# Altium schematic sheet Motor-E2.SchDoc(Motor-E2)
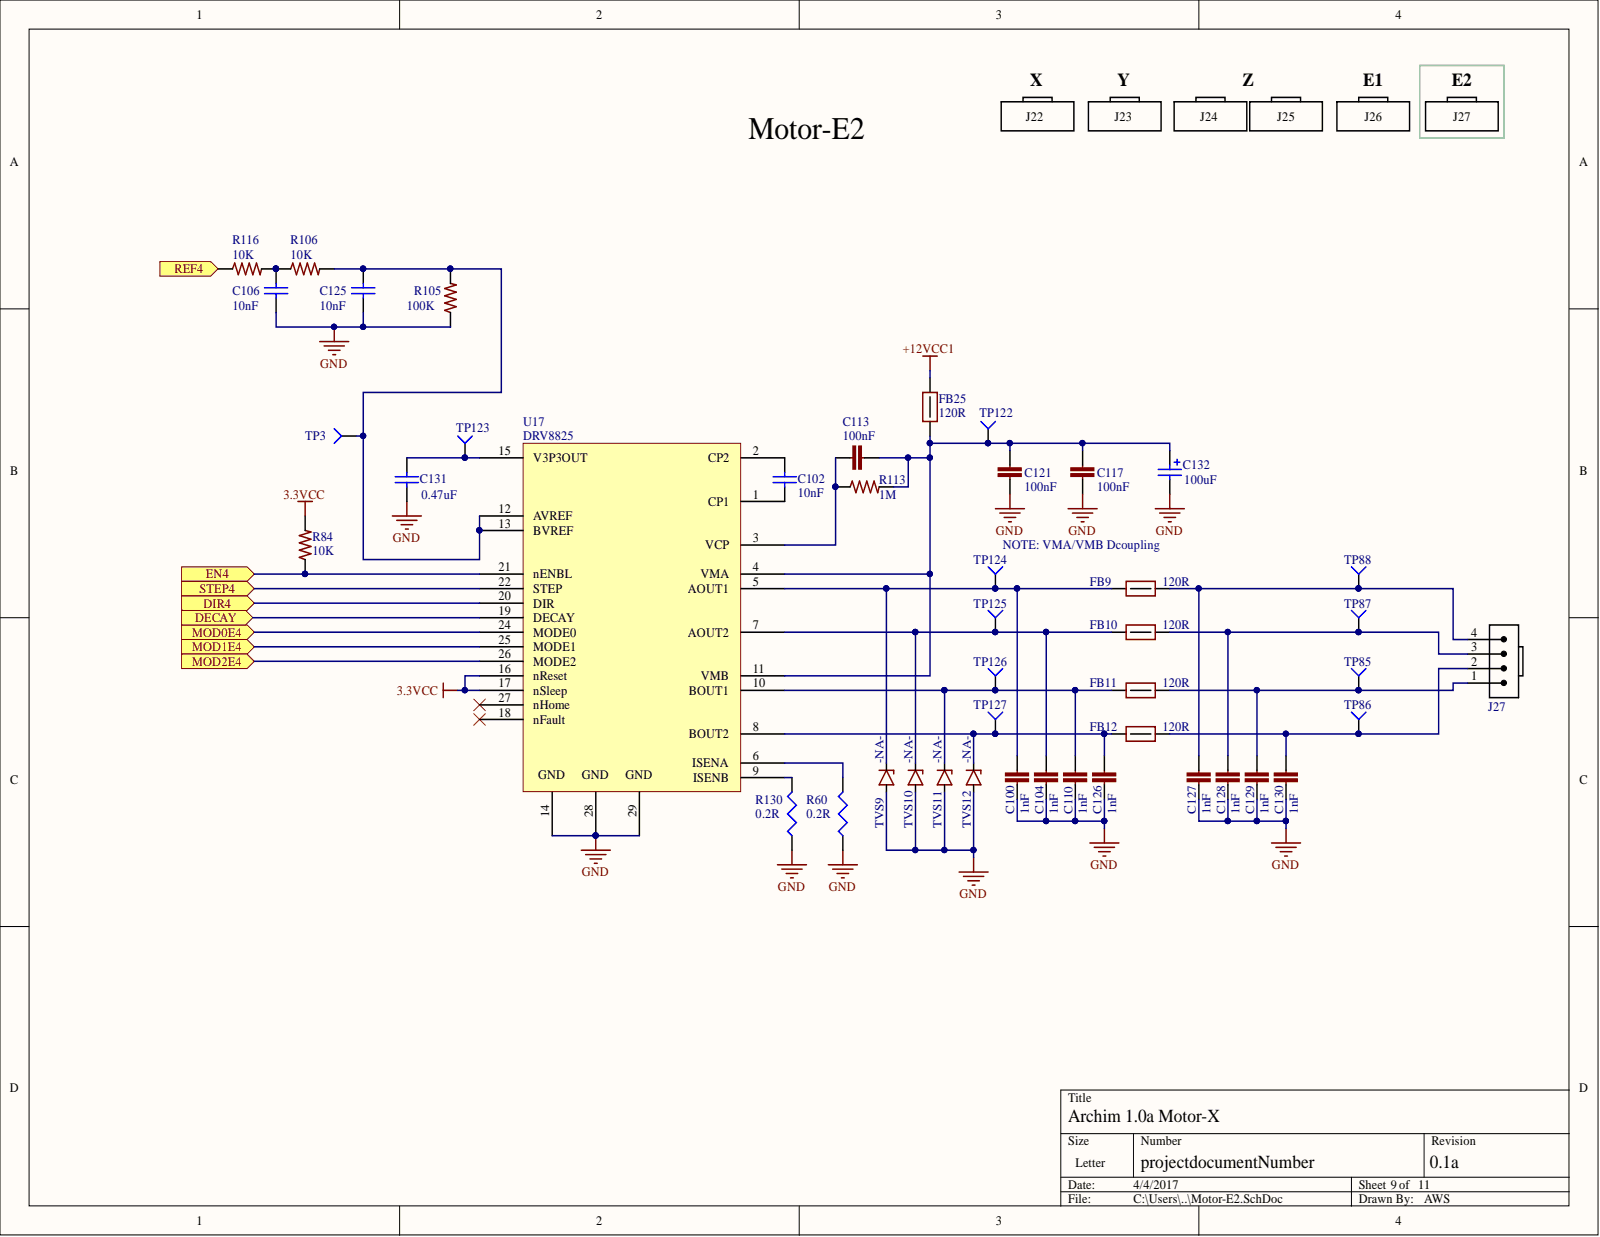
[diagram p0: Motor-E2.SchDoc]

## Parts
C100  1nF  [C0402]
C102  10nF  [C0402]
C104  1nF  [C0402]
C106  10nF  [C0402]
C110  1nF  [C0402]
C113  100nF  [C0402]
C117  100nF  [C0603]
C121  100nF  [C0603]
C125  10nF  [C0402]
C126  1nF  [C0402]
C127  1nF  [C0402]
C128  1nF  [C0402]
C129  1nF  [C0402]
C130  1nF  [C0402]
C131  value 0.47uF  [C0402]  (hidden comment: 0402)
C132  value 100uF  [CAP-ELEC-063063077]  (hidden comment: UWT1V101MCL1GS)
FB9  120R  [FB0603]
FB10  120R  [FB0603]
FB11  120R  [FB0603]
FB12  120R  [FB0603]
FB25  120R  [FB0603]
J27  Molex 0705430003  [4POL254]  (value not drawn)
R60  value 0.2R  [2512R-0.55]  (hidden comment: 2512)
R84  10K  [R0402]
R105  100K  [R0402]
R106  10K  [R0402]
R113  1M  [R0402]
R116  10K  [R0402]
R130  value 0.2R  [2512R-0.55]  (hidden comment: 2512)
TP3  TP4  [TP-2]  (value not drawn)  (no BOM)
TP85  TP4  [TP-2]  (value not drawn)  (no BOM)
TP86  TP4  [TP-2]  (value not drawn)  (no BOM)
TP87  TP4  [TP-2]  (value not drawn)  (no BOM)
TP88  TP4  [TP-2]  (value not drawn)  (no BOM)
TP122  TP4  [TP-2]  (value not drawn)  (no BOM)
TP123  TP4  [TP-2]  (value not drawn)  (no BOM)
TP124  TP4  [TP-2]  (value not drawn)  (no BOM)
TP125  TP4  [TP-2]  (value not drawn)  (no BOM)
TP126  TP4  [TP-2]  (value not drawn)  (no BOM)
TP127  TP4  [TP-2]  (value not drawn)  (no BOM)
TVS9  value -NA-  [SMF]  (hidden comment: TVS 30V SMF)
TVS10  value -NA-  [SMF]  (hidden comment: TVS 30V SMF)
TVS11  value -NA-  [SMF]  (hidden comment: TVS 30V SMF)
TVS12  value -NA-  [SMF]  (hidden comment: TVS 30V SMF)
U17  DRV8825  [DRV8825PWPR]

## Ports
DECAY, DIR4, EN4, MOD0E4, MOD1E4, MOD2E4, REF4, STEP4

## Nets
3.3VCC: R84.2 U17.16(nReset) U17.17(nSleep)
+12VCC1: FB25.2
GND: C100.2 C104.2 C106.1 C110.2 C117.2 C121.2 C125.1 C126.2 C127.2 C128.2 C129.2 C130.2 C131.1 C132.2 R60.1 R105.1 R130.1 TVS9.A TVS10.A TVS11.A TVS12.A U17.14 U17.28 U17.29
DECAY: U17.19(DECAY) ; port DECAY
DIR4: U17.20(DIR) ; port DIR4
EN4: R84.1 U17.21(nENBL) ; port EN4
MOD0E4: U17.24(MODE0) ; port MOD0E4
MOD1E4: U17.25(MODE1) ; port MOD1E4
MOD2E4: U17.26(MODE2) ; port MOD2E4
REF4: R116.1 ; port REF4
STEP4: U17.22(STEP) ; port STEP4
NetC100_1: C100.1 FB9.1 TP124.1 TVS9.K U17.5(AOUT1)
NetC102_1: C102.1 U17.1(CP1)
NetC102_2: C102.2 U17.2(CP2)
NetC104_1: C104.1 FB10.1 TP125.1 TVS10.K U17.7(AOUT2)
NetC106_2: C106.2 R106.1 R116.2
NetC110_1: C110.1 FB11.1 TP126.1 TVS11.K U17.10(BOUT1)
NetC113_1: C113.1 R113.1 U17.3(VCP)
NetC113_2: C113.2 C117.1 C121.1 C132.1 FB25.1 R113.2 TP122.1 U17.4(VMA) U17.11(VMB)
NetC125_2: C125.2 R105.2 R106.2 TP3.1 U17.12(AVREF) U17.13(BVREF)
NetC126_1: C126.1 FB12.1 TP127.1 TVS12.K U17.8(BOUT2)
NetC127_1: C127.1 FB9.2 J27.4 TP88.1
NetC128_1: C128.1 FB10.2 J27.3 TP87.1
NetC129_1: C129.1 FB11.2 J27.1 TP85.1
NetC130_1: C130.1 FB12.2 J27.2 TP86.1
NetC131_2: C131.2 TP123.1 U17.15(V3P3OUT)
NetR60_2: R60.2 U17.6(ISENA)
NetR130_2: R130.2 U17.9(ISENB)

Not connected: U17 18(nFault) 27(nHome)

## Library data of the parts
C100 C104 C110 C126-C130 = CAP 1nF 50V 0402 X7R: 1000pF 50V Ceramic Capacitor X7R 0402 (1005 Metric) 0.039" L x 0.020" W (1.00mm x 0.50mm); PART DESCRIPTION: 1000pF ±10% 50V Ceramic Capacitor X7R 0402 (1005 Metric); PART: 1nF 50V X7R 0402; MANUFACTURER #: GRM155R71H102KA01D; MANUFACTURER: Murata; TOLERANCE: 10%; VALUE: 1nF; Library Name: 3Delight.SCHLIB; EE_SPEC: 50V; DISTRIBUTOR #: 490-1303-2-ND; DISTRIBUTOR: Digikey; Component Kind: Standard
C102 C106 C125 = GRM155R71H103JA88D: CAP CER 10nF 50V X7R 0402; PART DESCRIPTION: 10000pF ±5% 50V Ceramic Capacitor X7R 0402 (1005 Metric); MANUFACTURER: Murata; TOLERANCE: 5%; VALUE: 10nF; Simulation: CAP; Signal Integrity: Cap Semi; PART: 10nF 50V X7R 0402; MANUFACTURER #: GRM155R71H103JA88D; Library Name: Tigershark3D.SchLib; EE_SPEC: 50V; DISTRIBUTOR #: 490-7763-1-ND; DISTRIBUTOR: Digikey; Component Kind: Standard
C113 = GRM155R71C104KA88D: CAP CER 0402 100nF 16V 10% X7R; PART DESCRIPTION: 0.10µF ±10% 16V Ceramic Capacitor X7R 0402 (1005 Metric); PART: 0.1uF 16V X7R 0402; MANUFACTURER: Murata; VALUE: 100nF; TOLERANCE: 10%; Simulation: Model Name; Signal Integrity: Model Name; MANUFACTURER #: GRM155R71C104KA88D; Library Name: 3Delight.SCHLIB; EE_SPEC: 16V; DISTRIBUTOR #: 490-3261-2-ND; DISTRIBUTOR: Digikey; Component Kind: Standard
C117 C121 = C0603C104K5RACTU: CAP CER 0603 100nF 50V 10% X7R; PART DESCRIPTION: 0.10µF ±10% 50V Ceramic Capacitor X7R 0603 (1608 Metric); PART: 100nF 50V X7R 0603; MANUFACTURER: Kemet; VALUE: 100nF; TOLERANCE: 10%; Simulation: Model Name; Signal Integrity: Model Name; MANUFACTURER #: C0603C104K5RACTU; Library Name: 3Delight.SCHLIB; EE_SPEC: 50V; DISTRIBUTOR #: 399-5089-2-ND; DISTRIBUTOR: Digikey; Component Kind: Standard
C131 = GRM155R60J474KE19D: 0.47uF 6.3v C0402 - GRM155R60J474KE19D; PART DESCRIPTION: 0.47µF ±10% 6.3V Ceramic Capacitor X5R 0402 (1005 Metric); PART: 0.47uF 6.3V X5R 0402; MANUFACTURER: Murata; TOLERANCE: 10%; Simulation: CAP; Signal Integrity: Cap Semi; MANUFACTURER #: GRM155R60J474KE19D; Library Name: Tigershark3D.SchLib; EE_SPEC: 6.3V; DISTRIBUTOR #: 490-3266-2-ND; DISTRIBUTOR: Digikey; Component Kind: Standard
C132 = UWT1V101MCL1GS: CAP ALUM 100UF 35V 20% SMD; PART DESCRIPTION: 100µF 35V Aluminum Capacitors Radial, Can - SMD 1000 Hrs @ 105°C; PART: 100uF 35V SMD Al-El; MANUFACTURER: Nichicon; TOLERANCE: 20%; Simulation: CAP; Signal Integrity: Cap Pol; MANUFACTURER #: UWT1V101MCL1GS; Library Name: 3Delight.SCHLIB; EE_SPEC: 35V; DISTRIBUTOR #: 493-2203-2-ND; DISTRIBUTOR: Digikey; Component Kind: Standard
FB9-FB12 FB25 = FERRITE 120R 3A 0603: FERRITE BEAD 120 OHM 0603 1LN; MANUFACTURER #: CIS10P121AC; MANUFACTURER2#: 490-5254-2-ND; MANUFACTURER: Samsung; VALUE: 120Rfb; TOLERANCE: -NA-; EE_SPEC: 3A; PART DESCRIPTION: FERRITE BEAD 120 OHM 0603 1LN; PART: FERRITE 120R 3A 0603; Library Name: 3Delight.SCHLIB; DISTRIBUTOR #: 1276-6351-2-ND; DISTRIBUTOR: Digikey; Component Kind: Standard
J27 = Molex 0705430003 Alt_1: Molex 4 Pin Clip Connector; PART DESCRIPTION: 4 Positions Header, Shrouded Connector 0.100" (2.54mm) Through Hole Tin; PART: 4 Pin Conn; MANUFACTURER #: 0705430038; VALUE: HDR4; TOLERANCE: -NA-; MANUFACTURER: Molex; EE_SPEC: -NA-; DISTRIBUTOR #: WM4826-ND; DISTRIBUTOR: Digikey; Component Kind: Standard; Library Name: Archim.SCHLIB
R60 R130 = CSRN2512FTR200: RES SMD 0.2 OHM 1% 2W 2512; PART DESCRIPTION: 0.2 Ohm ±1% 2W Chip Resistor 2512 (6432 Metric) Current Sense Thick Film; PART: 0.2R 1% 2512; MANUFACTURER #: CSRN2512FKR200; MANUFACTURER: Stackpole ELectronics Inc; TOLERANCE: 1%; Simulation: RESISTOR; Signal Integrity: Res; EE_SPEC: 2W; DISTRIBUTOR #: CSRN2512FKR200TR-ND; DISTRIBUTOR: Digikey; Component Kind: Standard; Library Name: Archim.SCHLIB
R84 R106 R116 = RC0402FR-0710KP: RES SMD 10K OHM 1% 1/16W 0402; PART DESCRIPTION: 10k Ohm ±1% 0.063W, 1/16W Chip Resistor 0402 (1005 Metric) Moisture Resistant Thick Film; PART: 10k 1% 0402; MANUFACTURER: Yageo; VALUE: 10K; TOLERANCE: 1%; Simulation: Model Name; Signal Integrity: Model Name; MANUFACTURER #: RC0402FR-0710KP; Library Name: 3Delight.SCHLIB; EE_SPEC: 1/16W; DISTRIBUTOR #: YAG1249TR-ND; DISTRIBUTOR: Digikey; Component Kind: Standard
R105 = RC1005F104CS: RES SMD 100K OHM 1% 1/16W 0402; PART DESCRIPTION: 100k Ohm ±1% 0.063W, 1/16W Chip Resistor 0402 (1005 Metric) Moisture Resistant Thick Film; PART: 100k 1% 0402; MANUFACTURER: Samsung; VALUE: 100K; TOLERANCE: 1%; Simulation: Model Name; Signal Integrity: Model Name; MANUFACTURER #: RC1005F104CS; Library Name: Tigershark3D.SchLib; EE_SPEC: 1/16W; DISTRIBUTOR #: 1276-3432-1-ND; DISTRIBUTOR: Digikey; Component Kind: Standard
R113 = ERJ2GEJ105X: RES 0402 1M 100mW 5%; PART DESCRIPTION: 1M Ohm ±5% 0.1W, 1/10W Chip Resistor 0402 (1005 Metric) Automotive AEC-Q200 Thick Film; PART: 1M 5% 0402; MANUFACTURER: Panasonic; EE_SPEC: 1/10W; VALUE: 1M; TOLERANCE: 5%; Simulation: Model Name; Signal Integrity: Model Name; MANUFACTURER #: ERJ2GEJ105X; Library Name: Tigershark3D.SchLib; DISTRIBUTOR #: P1.0MJTR-ND; DISTRIBUTOR: Digikey; Component Kind: Standard
TP3 TP85-TP88 TP122-TP127 = Socket: MANUFACTURER #: NOTAPART-Testpoint; Signal Integrity: Connector; Description: Socket; Library Name: Archim.SCHLIB
TVS9-TVS12 = TVS 30V SMF: TVS DIODE 30VWM 48.4VC SMF; PART: TVS Diode 48.4VC; MANUFACTURER #: SMF30A-E3-08; MANUFACTURER: Vishay; EE_SPEC: 30Vrs 48.4Vc; TOLERANCE: -NA-; PART DESCRIPTION: TVS DIODE 30VWM 48.4VC SMF; Library Name: 3Delight.SCHLIB; DISTRIBUTOR #: SMF30A-E3-08GITR-ND; DISTRIBUTOR: Digikey; Component Kind: Standard
U17 = DRV8825: DRV8825 Ti Stepper Driver; PART DESCRIPTION: Bipolar Motor Driver Power MOSFET Step/Direction 28-HTSSOP; MANUFACTURER: Texas Instruments; VALUE: -NA-; EE_SPEC: 8.2-45VDC; TOLERANCE: -NA-; PART: DRV8825; MANUFACTURER #: DRV8825PWPR; Library Name: Tigershark3D.SchLib; DISTRIBUTOR #: 296-29503-2-ND; DISTRIBUTOR: Digikey; Component Kind: Standard
